# Altium schematic sheet BUTTON_LED.SchDoc(BUTTON_LED)
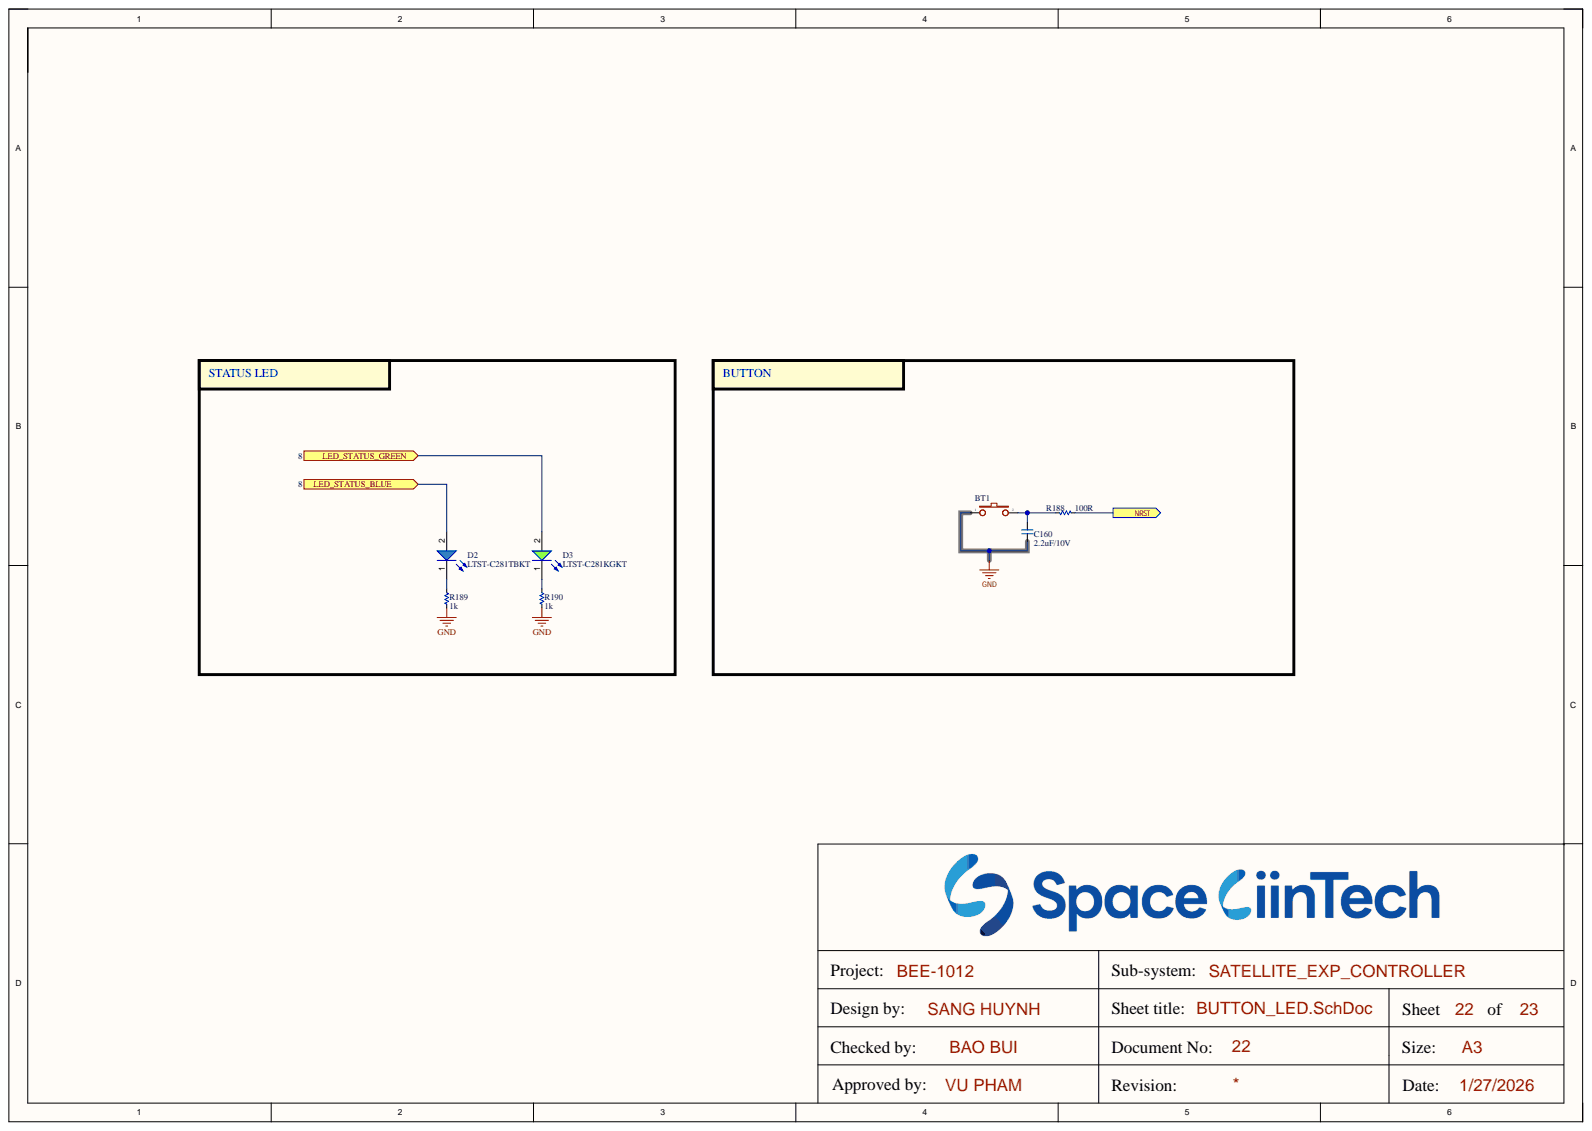
[diagram p0: BUTTON_LED.SchDoc]

## Parts
BT1  BT_  [SW2-SMD]
C160  2.2uF/10V  [CAP_C_1005X50_L]
D2  LTST-C281TBKT  [LED_0402]
D3  LTST-C281KGKT  [LED_0402]
R188  100R  [RES_C_1005x30_L]
R189  1k  [RES_C_1005x30_L]
R190  1k  [RES_C_1005x30_L]

## Ports
LED_STATUS_BLUE, LED_STATUS_GREEN, NRST

## Nets
GND: BT1.1 C160.1 R189.1 R190.1
LED_STATUS_BLUE: D2.2 ; port LED_STATUS_BLUE
LED_STATUS_GREEN: D3.2 ; port LED_STATUS_GREEN
NRST: R188.2 ; port NRST
NetBT1_2: BT1.2 C160.2 R188.1
NetD2_1: D2.1 R189.2
NetD3_1: D3.1 R190.2

## Library data of the parts
BT1 = BT2: Switch button 2 pin; Value: SW chip 2 pin; Component Kind: Standard; Library Name: IFBschelib.SchLib
C160 = _CAP_C_2: CAP CHIP; Component Kind: Standard; Library Name: IFBschelib.SchLib
D2 = LED_BLUE: Component Kind: Standard; Library Name: IFBschelib.SchLib
D3 = LED_GREEN: LED GREEN CLEAR 0402 SMD; Component Kind: Standard; Library Name: IFBschelib.SchLib
R188-R190 = _RES_B_2: RES; Component Kind: Standard; Library Name: IFBschelib.SchLib
